# Altium schematic sheet Arduino_Board.SchDoc
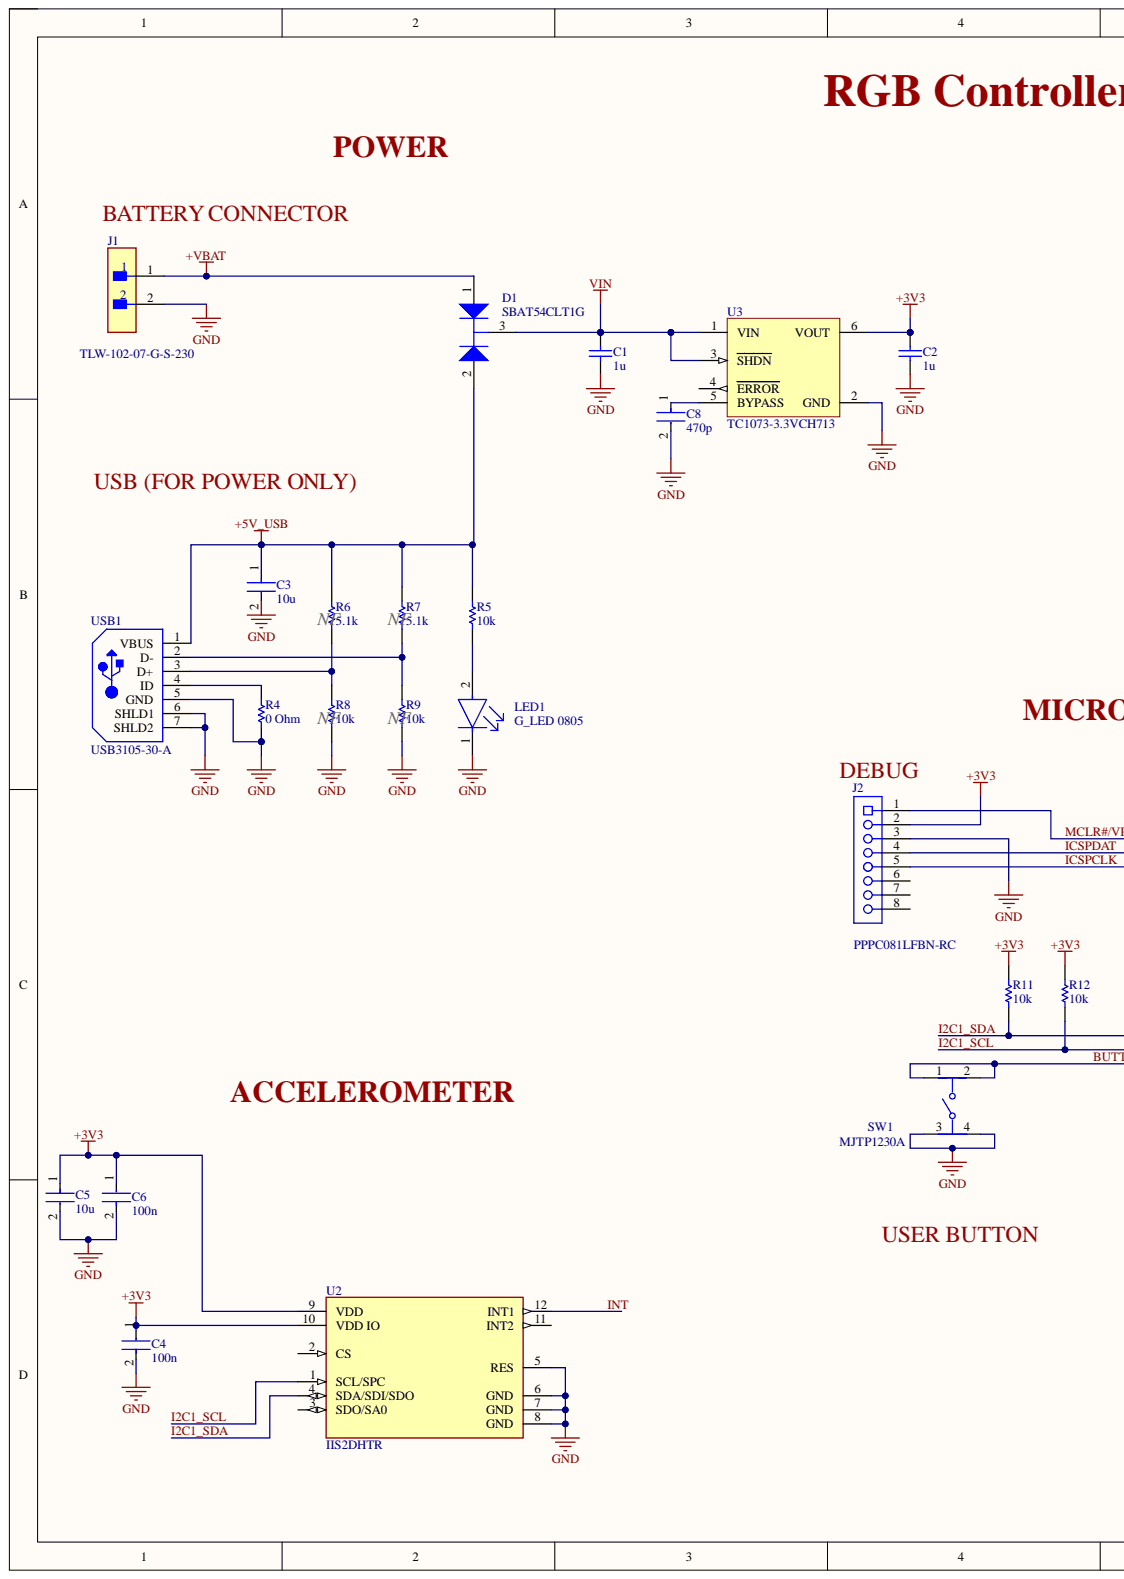
[diagram p0: Arduino_Board.SchDoc, left]
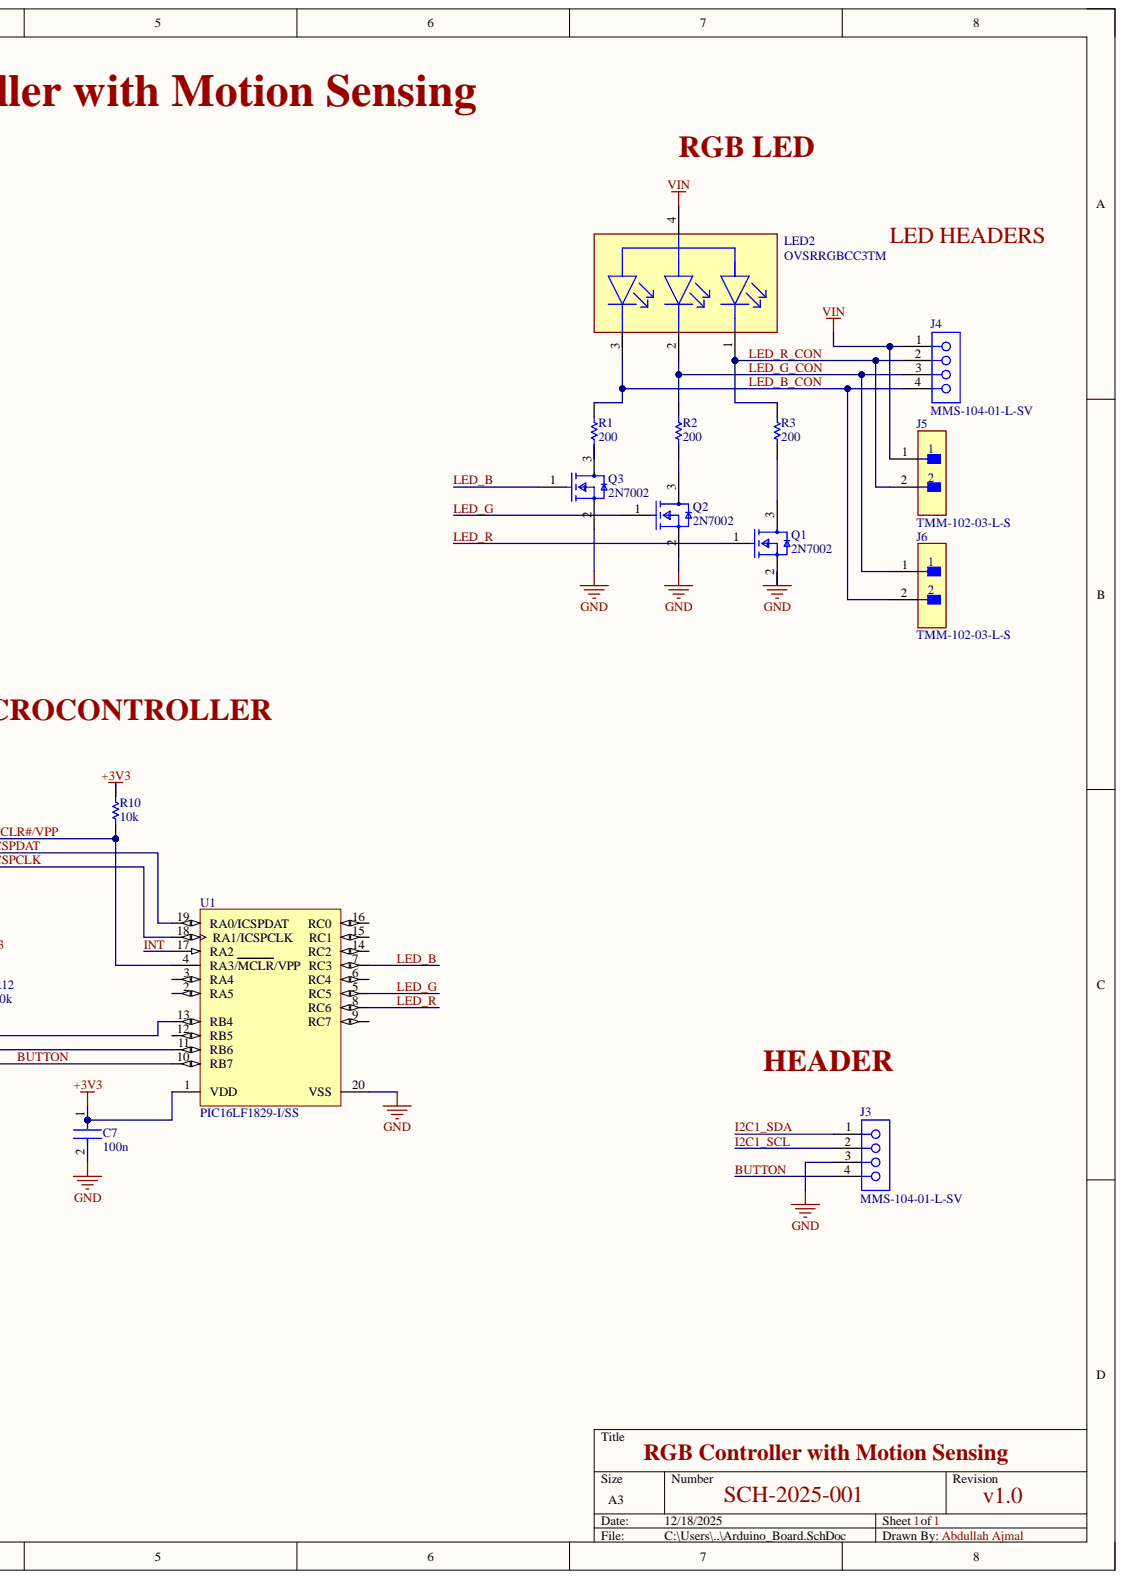
[diagram p1: Arduino_Board.SchDoc, right]

## Parts
C1  1u  [CAPC2013X140X50NL20T25]
C2  1u  [CAPC2013X140X50NL20T25]
C3  10u  [FP-GRM188-0_2-MFG]
C4  100n  [FP-AC1210-0_85-MFG]
C5  10u  [FP-GRM188-0_2-MFG]
C6  100n  [FP-AC1210-0_85-MFG]
C7  100n  [FP-AC1210-0_85-MFG]
C8  470p  [FP-C0402-BB-MFG]
D1  SBAT54CLT1G  [ONSC-SOT-23-3-318-08_V]
J1  TLW-102-07-G-S-230  [FP-MTLW-102-07-G-S-230-MFG]
J2  PPPC081LFBN-RC  [SLIN-PPPC081LFBN-RC_V]
J3  MMS-104-01-L-SV  [SMTC-MMS-104-01-X-SV]
J4  MMS-104-01-L-SV  [SMTC-MMS-104-01-X-SV]
J5  TMM-102-03-L-S  [FP-TMM-102-03-L-S-MFG]
J6  TMM-102-03-L-S  [FP-TMM-102-03-L-S-MFG]
LED1  G_LED 0805  [FP-LG_R971-MFG]
LED2  OVSRRGBCC3TM  [FP-OVSRRGBCC3TM-MFG]
Q1  2N7002  [FP-PG-SOT23-3-MFG]
Q2  2N7002  [FP-PG-SOT23-3-MFG]
Q3  2N7002  [FP-PG-SOT23-3-MFG]
R1  200  [RESC3225X60X45ML20T20]
R2  200  [RESC3225X60X45ML20T20]
R3  200  [RESC3225X60X45ML20T20]
R4  0 Ohm  [RESC3116X65X45LL15T20]
R5  10k  [RESC1005X40X20NL05T10]
R6  5.1k  [RESC1005X35X20LL05T10]
R7  5.1k  [RESC1005X35X20LL05T10]
R8  10k  [RESC1005X40X20NL05T10]
R9  10k  [RESC1005X40X20NL05T10]
R10  10k  [RESC1005X40X20NL05T10]
R11  10k  [RESC1005X40X20NL05T10]
R12  10k  [RESC1005X40X20NL05T10]
SW1  MJTP1230A  [FP-MJTP1230A-MFG]
U1  PIC16LF1829-I/SS  [MCHP-SSOP-SS20_L]
U2  IIS2DHTR  [FP-LGA-12-8365767-MFG]
U3  TC1073-3.3VCH713  [SOT-23-CH6_M]
USB1  USB3105-30-A  [GCT-USB3105-30-A_V]

## Nets
+3V3: C2.2 C4.1 C5.1 C6.1 C7.1 J2.2 R10.2 R11.2 R12.2 U1.1(VDD) U2.9(VDD) U2.10(VDD_IO) U3.6(VOUT)
+5V_USB: C3.1 D1.2 R5.2 R6.2 R7.2 USB1.1(VBUS)
+VBAT: D1.1 J1.1
GND: C1.1 C2.1 C3.2 C4.2 C5.2 C6.2 C7.2 C8.2 J1.2 J2.3 J3.3 LED1.1 Q1.2 Q2.2 Q3.2 R4.1 R8.1 R9.1 SW1.3 SW1.4 U1.20(VSS) U2.5(RES) U2.6(GND) U2.7(GND) U2.8(GND) U3.2(GND) USB1.5(GND) USB1.6(SHLD1) USB1.7(SHLD2)
VIN: C1.2 D1.3 J4.1 J5.1 LED2.4 U3.1(VIN) U3.3(SHDN)
BUTTON: J3.4 SW1.1 SW1.2 U1.10(RB7)
I2C1_SCL: J3.2 R12.1 U1.11(RB6) U2.1(SCL/SPC)
I2C1_SDA: J3.1 R11.1 U1.13(RB4) U2.4(SDA/SDI/SDO)
ICSPCLK: J2.5 U1.18(RA1/ICSPCLK)
ICSPDAT: J2.4 U1.19(RA0/ICSPDAT)
INT: U1.17(RA2) U2.12(INT1)
LED_B: Q3.1 U1.7(RC3)
LED_B_CON: J4.4 J6.2 LED2.3 R1.2
LED_G: Q2.1 U1.5(RC5)
LED_G_CON: J4.3 J6.1 LED2.2 R2.2
LED_R: Q1.1 U1.8(RC6)
LED_R_CON: J4.2 J5.2 LED2.1 R3.2
MCLR#/VPP: J2.1 R10.1 U1.4(RA3/MCLR/VPP)
NetC8_1: C8.1 U3.5(BYPASS)
NetLED1_2: LED1.2 R5.1
NetQ1_3: Q1.3 R3.1
NetQ2_3: Q2.3 R2.1
NetQ3_3: Q3.3 R1.1
NetR4_2: R4.2 USB1.4(ID)
NetR6_1: R6.1 R8.2 USB1.3(D+)
NetR7_1: R7.1 R9.2 USB1.2(D-)

Not connected: J2 6 7 8; U1 2(RA5) 3(RA4) 6(RC4) 9(RC7) 12(RB5) 14(RC2) 15(RC1) 16(RC0); U2 2(CS) 3(SDO/SA0) 11(INT2); U3 4(ERROR)

## Library data of the parts
C1 C2 = CMP-2007-03237-1: Manufacturer: Kemet; Part Number: C0805C105M4PACTU; Component Kind: Standard; Library Name: Altium Content Vault
C3 C5 = CMP-06035-009669-1: Chip Multilayer Ceramic Capacitors for General Purpose, 0603, 10uF, X5R, 15%, 20%, 16V  GRM188R61C106MA73J; Capacitance: 10uF; Component Kind: Standard; Dielectric: X5R; Length: 1.6mm; Library Name: Altium Content Vault; Thickness: 800um; Tolerance: 20%; Voltage Rating (DC): 16V; Width: 800um
C4 C6 C7 = CMP-03422-000642-1: CAP CER 0.1UF 100V X7R 1210  AC1210KKX7R0BB104; Manufacturer: Yageo; Automotive Grade: Grade 1; Capacitance: 100 nF; Material: Ceramic; Temperature Coefficient: 15%; Tolerance: 0.1; Value: 100 nF; Automotive: Yes; Category: Cap; Component Kind: Standard; ComponentLink2Description: Datasheet; ComponentLink2URL: http://www.yageo.com/documents/recent/UPY-AC_NP0X7R_16V-to-630V_12.pdf; Device Class L1: Passive Components; Device Class L2: Capacitors; Device Class L3: Ceramic Capacitors; Digikey Description: CAP CER 0.1UF 100V X7R 1210; Footprint Url: http://www.yageo.com/exep/pages/download/literatures/UPY-C_GEN_24.pdf; Height: 0.95mm; Ipc Land Pattern Name: CAPC320250X85; Lead Free: Yes; Library Name: Altium Content Vault; Manufacturer Part Number: AC1210KKX7R0BB104; Octopart: https://octopart.com/ac1210kkx7r0bb104-yageo-78873719; Package: AC1210-0.85; RoHS: TRUE; Temperature: -55°C to +125°C; Temperature Characteristic: X7R; Temperature Range High: +125°C; Temperature Range Low: -55°C; Voltage Rating: 100 V
C8 = CMP-03020-005716-2: C0402 470 pF C0G 10.00% 16 V; Manufacturer: Kemet; Manufacturer Part Number: C0402C471K4GACAUTO; Capacitance: 470 pF; Material: Ceramic; Temperature Coefficient: 15%; Tolerance: 0.1; Value: 470 pF; Voltage Rating: 16 V; Automotive: No; Category: Cap; Component Kind: Standard; ComponentLink2Description: Datasheet; ComponentLink2URL: https://content.kemet.com/datasheets/KEM_C1002_X7R_SMD.pdf; Device Class L1: Passive Components; Device Class L2: Capacitors; Device Class L3: Ceramic Capacitors; Height: 0.55mm; Ipc Land Pattern Name: CAPC10050X50; Lead Free: Yes; Library Name: Altium Content Vault; Octopart: https://octopart.com/c0402c471k4gacauto-kemet-48652786; Package: C0402-BB; RoHS: TRUE; Temperature: -55°C to +125°C; Temperature Characteristic: C0G; Temperature Range High: +125°C; Temperature Range Low: -55°C
D1 = CMP-1055-00546-1: Dual Common Cathode Schottky Barrier Diodes, 3-Pin SOT-23, Pb-Free, Tape and Reel; Manufacturer: On Semiconductor; IFSM Max (A): 0.6; trr Max (ns): 5; Component Kind: Standard; ComponentLink1Description: Manufacturer URL; ComponentLink1URL: http://www.onsemi.com/; ComponentLink2Description: Datasheet; ComponentLink2URL: http://www.onsemi.cn/pub_link/Collateral/BAT54CLT1-D.PDF; ComponentLink3Description: Package Specification; ComponentLink3URL: http://www.onsemi.cn/pub_link/Collateral/318-08.PDF; Configuration: Common Cathode; DatasheetVersion: Rev. 8; Library Name: Altium Content Vault; Mounting Technology: Surface Mount; PackageDescription: 3-Pin Small Outline Transistor, Body 2.92 x 1.3 mm, Pitch 0.95 mm; PackageReference: SOT-23-3-318-08; PackageVersion: Rev. AP, 11/2009; Packing: Tape and Reel; PartNumber: SBAT54CLT1G; RoHS: TRUE
J1 = CMP-02766-001053-1: CONN HEADER VERT 2POS 2.54  MMMTLW-102-07-G-S-230; Gender: Male; Max Current Rating: 3A; Number of Contacts: 2; Pitch: 2.54mm; Component Kind: Standard; Contact Material: Bronze; Contact Plating: Gold; Depth: 2.48mm; Housing Color: Black; Housing Material: Polymer; Length: 5.08mm; Library Name: Altium Content Vault; Max Operating Temperature: 125°C; Min Operating Temperature: -55°C; Number of Rows: 1; Orientation: Straight; Packaging: Bulk; Radiation Hardening: No; Termination: Solder
J2 = CMP-002-00028-2: Female Header, Pitch 2.54 mm, 1 x 8 Position, Height 8.5 mm, Tail Length 3.2 mm, -40 to 105 degC, RoHS, Bulk; Manufacturer: Sullins; Case/Package: PPPC081LFBN-RC; Component Kind: Standard; Connector Type: Header; Datasheet URL: http://sullinscorp.com/catalogs/101_PAGE114-115_.100_FEMALE_HDR.pdf; Datasheet Version: Jan-15; Gender: Female; Library Name: WePCB Projects; Max Operating Temperature: 105°C; Min Operating Temperature: -40°C; Mounting Technology: TH; Package Description: Female Header, Pitch 2.54 mm, 1 x 8 Position, Height 8.5 mm, Tail Length 3.2 mm; Package Reference: PPPC081LFBN-RC; RoHS Compliant: Unknown
J3 J4 = CMP-1022-00067-1: Tiger Claw(TM) Socket Strip, Through-hole, Vertical, -55 to 125 degC, 2 mm Pitch, 4-Pin, Female, RoHS; Manufacturer: Samtec; Pitch: 2 mm; Component Kind: Standard; ComponentLink1Description: Manufacturer URL; ComponentLink1URL: http://www.samtec.com; ComponentLink2Description: Datasheet; ComponentLink2URL: http://www.samtec.com/documents/webfiles/pdf/MMS.PDF; ComponentLink3Description: Package Specification; ComponentLink3URL: http://www.samtec.com/documents/webfiles/cpdf/MMS-1XX-01-XXX-XX-XXX-MKT1.pdf; DatasheetVersion: F-214; Library Name: Altium Content Vault; Mounting Technology: Through-hole; Orientation: Vertical; PackageDescription: 2mm Through Hole Box Connector Strip Assembly; PackageReference: MMS-104-01-X-SV; PackageVersion: AO, 2/1993; PartNumber: MMS-104-01-L-SV; RoHS: True; Temperature Range: -55 to 125 degC
J5 J6 = CMP-02766-001502-1: CONN HEADER 2POS SNGL 2MM T/H; Gender: Male; Max Current Rating: 3.9A; Max Voltage Rating (AC): 500V; Number of Contacts: 2; Color: Black; Component Kind: Standard; Connector Type: Header; Contact Finish - Mating: GOLD; Contact Material: Bronze; Contact Mating Length: 0.157inch; Contact Plating: Gold; Contact Shape: Square; Contact Type: Male Pin; Depth: 1.97mm; Fastening Type: Pull; Housing Color: Black; Housing Material: Polymer; Insulation Color: Black; Insulation Material: Polymer; Lead Free: Lead Free; Length: 4mm; Library Name: Altium Content Vault; Max Operating Temperature: 125°C; Min Operating Temperature: -55°C; Mount: Through Hole; Number of Positions: 2; Number of Rows: 1; Orientation: Straight; Package Quantity: 1; Packaging: Bulk; Pitch: 2mm; Radiation Hardening: No; REACH SVHC: No SVHC; RoHS Compliant: Yes; Series: TMM; Termination: Solder
LED1 = CMP-62016-000039-1: LED GREEN DIFFUSED 0805 SMD  Q62702P5179; Dominant Wavelength: 570nm; Forward Current: 25mA; Forward Voltage: 2.2V; Lens Color: White; Lens Size: 1.25mm; Luminous Flux: 0.1lm; Luminous Intensity: 45mcd; Number of Elements: 1; Power Dissipation: 65mW; Reverse Voltage: 12V; Test Current: 20mA; Viewing Angle: 160°; Case Code (Imperial): 0805; Case Code (Metric): 2012; Case/Package: 0805; Color: Green; Component Kind: Standard; Depth: 1.35mm; Height: 0.9mm; Illumination Color: Green; Length: 2.1mm; Lens Style: Diffused; Lens Transparency: Diffused; Library Name: Altium Content Vault; Max Operating Temperature: 85°C; Min Operating Temperature: -30°C; Mount: Surface Mount; Number of LEDs: 1; Number of Pins: 2; Package Quantity: 1; Packaging: Tape and Reel; Radiation Hardening: No; Width: 1.25mm
LED2 = CMP-70659-000001-1: LED RGB DIFFUSED 1204 SMD; Color: Blue; Dominant Wavelength: 475nm; Forward Voltage: 3.3V; Lens Color: White; Lens Style: Dome; Luminous Intensity: 200mcd; Test Current: 20mA; Viewing Angle: 150°; Case/Package: SMD/SMT; Component Kind: Standard; Height: 1.5mm; Lead Free: Lead Free; Length: 3.2mm; Lens Transparency: Diffused; Library Name: Altium Content Vault; Mount: Surface Mount; Packaging: Cut Tape; REACH SVHC: No SVHC; RoHS Compliant: Yes; Series: -; Width: 1mm
Q1-Q3 = CMP-32901-000180-1: MOSFET N-CH 60V 0.3A SOT23  2N7002H6327XTSA2; Continuous Drain Current (ID): 300mA; Gate to Source Voltage (Vgs): 20V; Input Capacitance: 20pF; Max Power Dissipation: 500mW; Rds On Max: 3R; Rise Time: 3.3ns; Case/Package: SOT-23; Component Kind: Standard; Drain to Source Voltage (Vdss): 60V; Halogen Free: Halogen Free; Lead Free: Lead Free; Library Name: Altium Content Vault; Max Dual Supply Voltage: 60V; Max Operating Temperature: 150°C; Min Operating Temperature: -55°C; Mount: Surface Mount; Number of Pins: 3; Packaging: Tape and Reel
R1-R3 = CMP-2005-00653-1: CRCW1210200RJNTA; Manufacturer: Vishay Dale; Component Kind: Standard; Library Name: Altium Content Vault; Part Number: CRCW1210200RJNTA
R4 = CMP-2000-07493-1: Chip Resistor, 0 Ohm, +/- 0%, 0.25 W, -55 to 155 degC, 1206 (3216 Metric), RoHS, Tape and Reel  CRG1206ZR; Manufacturer: TE Connectivity; Case\/Package: 1206; Number of Resistors: 1; Power Rating: 250mW; Resistance: 0mOhm; Temperature Coefficient: 100ppm\/degreesC; Voltage Rating: 200V; Case Code (Imperial): 1206; Case Code (Metric): 3216; Component Kind: Standard; Composition: ThickFilm; Datasheet URL: http://www.te.com/commerce/DocumentDelivery/DDEController?Action=showdoc&DocId=Customer+Drawing%7F1623693%7FBA%7Fpdf%7FEnglish%7FENG_CD_1623693_BA.pdf%7F1623693-1; Datasheet Version: Rev. BA, 12/2009; Depth: 1.55mm; ELV: Compliant; Height: 0.55mm; Length: 3.1mm; Library Name: Altium Content Vault; Manufacturer URL: http://www.te.com/; Max Operating Temperature: 125degC; Min Operating Temperature: -55degC; Mount: SurfaceMount; Mounting Technology: SurfaceMount; Number of Terminations: 2; Package Description: 2-Pin Chip Resistor, Body 3.1 x 1.55 mm; Package Quantity: 5000; Package Reference: RESC3116X65X45L15T20; Packaging: TapeandReel; Radiation Hardening: No; RoHSCompliant: true; Termination: Solder; Tolerance: 0%; Weight: 0.000571oz; Width: 1.55mm
R5 R8-R12 = CMP-2002-01682-1: TNPW040210K0DEED; Manufacturer: Vishay BCcomponents; Component Kind: Standard; Library Name: Altium Content Vault; Part Number: TNPW040210K0DEED
R6 R7 = CMP-2002-03342-1: CPF0402B5K1E1; Manufacturer: TE Connectivity / AMP; Component Kind: Standard; Library Name: Altium Content Vault; Part Number: CPF0402B5K1E1
SW1 = CMP-47024-000004-1: SWITCH TACTILE SPST-NO 0.05A 12V; Actuator Color: Black; Actuator Length: 1.4mm; Actuator Material: Thermoplastic; Actuator Type: Standard; Contact Resistance: 100mR; Current Rating: 50mA; Electrical Life: 100000Cycles; Illumination Type: Non-Illuminated; Operating Force: 160gf; Switch Function: Off-Mom; Switch Travel: 0.25mm; Throw Configuration: SPST; Color: Black; Component Kind: Standard; Contact Material: Bronze; Contact Plating: Silver; Library Name: Altium Content Vault; Max Operating Temperature: 70°C; Max Voltage Rating (DC): 12V; Mechanical Life: 100000Cycles; Min Operating Temperature: -20°C; Mount: Through Hole; Orientation: Straight; Package Quantity: 2000; Packaging: Bulk; REACH SVHC: No SVHC; RoHS Compliant: Yes; Series: MJTP; Termination: PC Pin; Voltage Rating (DC): 12V
U1 = CMP-0115-02781-1: Flash Microcontroller with XLP Technology, 32 MHz, 17 I/O, -40 to 85 degC, 20-pin SSOP (SS20), Tube; Manufacturer: Microchip; # of A/D Channels: 12; Digital Communication: 1 -A/E/USART, 2 -MSSP(SPI/I2C); EEPROM Data Memory (bytes): 256; I/O Pins: 17; Internal Oscillator: 32 MHz, 31 kHz; Max. CPU Speed MHz: 32; Operation Voltage Range: 1.8V - 3.6V; Program Memory KBytes: 14; Program Memory KWords: 8; RAM: 1024; Timers: 4 - 8-bit, 1 - 16-bit, 0 - 32-bit; Component Kind: Standard; ComponentLink1Description: Datasheet; ComponentLink1URL: http://ww1.microchip.com/downloads/en/DeviceDoc/41440C.pdf; ComponentLink2Description: Package Specification; ComponentLink2URL: http://www.microchip.com/stellent/groups/techpub_sg/documents/packagingspec/en012702.pdf; ComponentLink3Description: URL Link1; ComponentLink3URL: Product URL:http://www.microchip.com/wwwproducts/Devices.aspx?dDocName=en546919; DatasheetVersion: Rev. C; Library Name: Altium Content Vault; Memory Type: Flash; Mounting Technology: Surface Mount; PackageDescription: 20-Lead Plastic Shrink Small Outline, 5.3 x 7.2 mm Body, 0.65 mm Pitch; PackageReference: SSOP-SS20; PackageVersion: C04-072B, 12/2012; Packing: TUBE; PartNumber: PIC16LF1829-I/SS
U2 = CMP-12175-000008-1: ACCEL 2-16G I2C/SPI 12LGA; Manufacturer: STMicroelectronics; Accel Axis: X+Y and Z; Accel Range: 2/4/8/16g; Max Supply Voltage: 3.7V; Min Supply Voltage: 1.71V; Operating Supply Current: 2-11uA; Sensor Output: I2C,SPI; Automotive: No; Category: IC; Component Kind: Standard; ComponentLink2Description: Datasheet; ComponentLink2URL: https://www.st.com/content/ccc/resource/technical/document/datasheet/group1/35/6d/04/26/27/5c/4d/7c/DM00171283/files/DM00171283.pdf/jcr:content/translations/en.DM00171283.pdf; Device Class L1: Sensors; Device Class L2: Motion Sensors; Device Class L3: Accelerometers; Digikey Description: ACCEL 2-16G I2C/SPI 12LGA; Height: 1mm; Lead Free: Yes; Library Name: Altium Content Vault; Manufacturer Part Number: IIS2DHTR; Octopart: https://octopart.com/iis2dhtr-stmicroelectronics-58218805; Package: LGA-12-8365767; RoHS: TRUE; Temperature: -40°C to +85°C; Temperature Range High: +85°C; Temperature Range Low: -40°C
U3 = CMP-0136-00636-1: 50mA and 100mA CMOS LDOs with Shutdown, Active-Low Error Output and VREF Bypass, 6-Pin SOT-23, Extended Temperature, Tape and Reel; Code_IPC: SOT26A-6; Component Kind: Standard; DatasheetVersion: revD, Feb-2007; Library Name: Altium Content Vault; PackageDescription: 6-Lead Plastic Small Outline Transistor (CH) [SOT-23]; PackageReference: SOT-23-CH6; PackageVersion: revBB, Aug-2009
USB1 = CMP-2000-07366-1: USB 2.0 A/B Receptacle, 30 V, -55 to 85 degC, 5-Pin THD, RoHS, Tape and Reel; Manufacturer: Global Connector Technology; Connector Mounting: ThroughHoleMount; No. of Positions: 5Ways; USB Connector Type: MicroUSBTypeAB; USB Standard: USB2.0; Component Kind: Standard; Contact Material: CopperAlloy; Contact Plating: GoldPlatedContacts; Datasheet URL: http://gct.co/usb-connectors/pdf/USB3105.pdf; Datasheet Version: Rev. F, 05/2015; Gender: Receptacle; Library Name: Altium Content Vault; Manufacturer URL: http://gct.co/; Mounting Technology: ThroughHole; Orientation: Vertical; Package Description: 5-Pin Through Hole Device, Body 7.96 x 2.9 mm, Pitch 0.65 mm; Package Reference: USB3105-30-A; Product Range: -
